# Altium schematic sheet CONFIGURATION_STORAGE_USER_LEDS_BUTTONS.SchDoc(CONFIGURATION_STORAGE_USER_LEDS_BUTTONS)
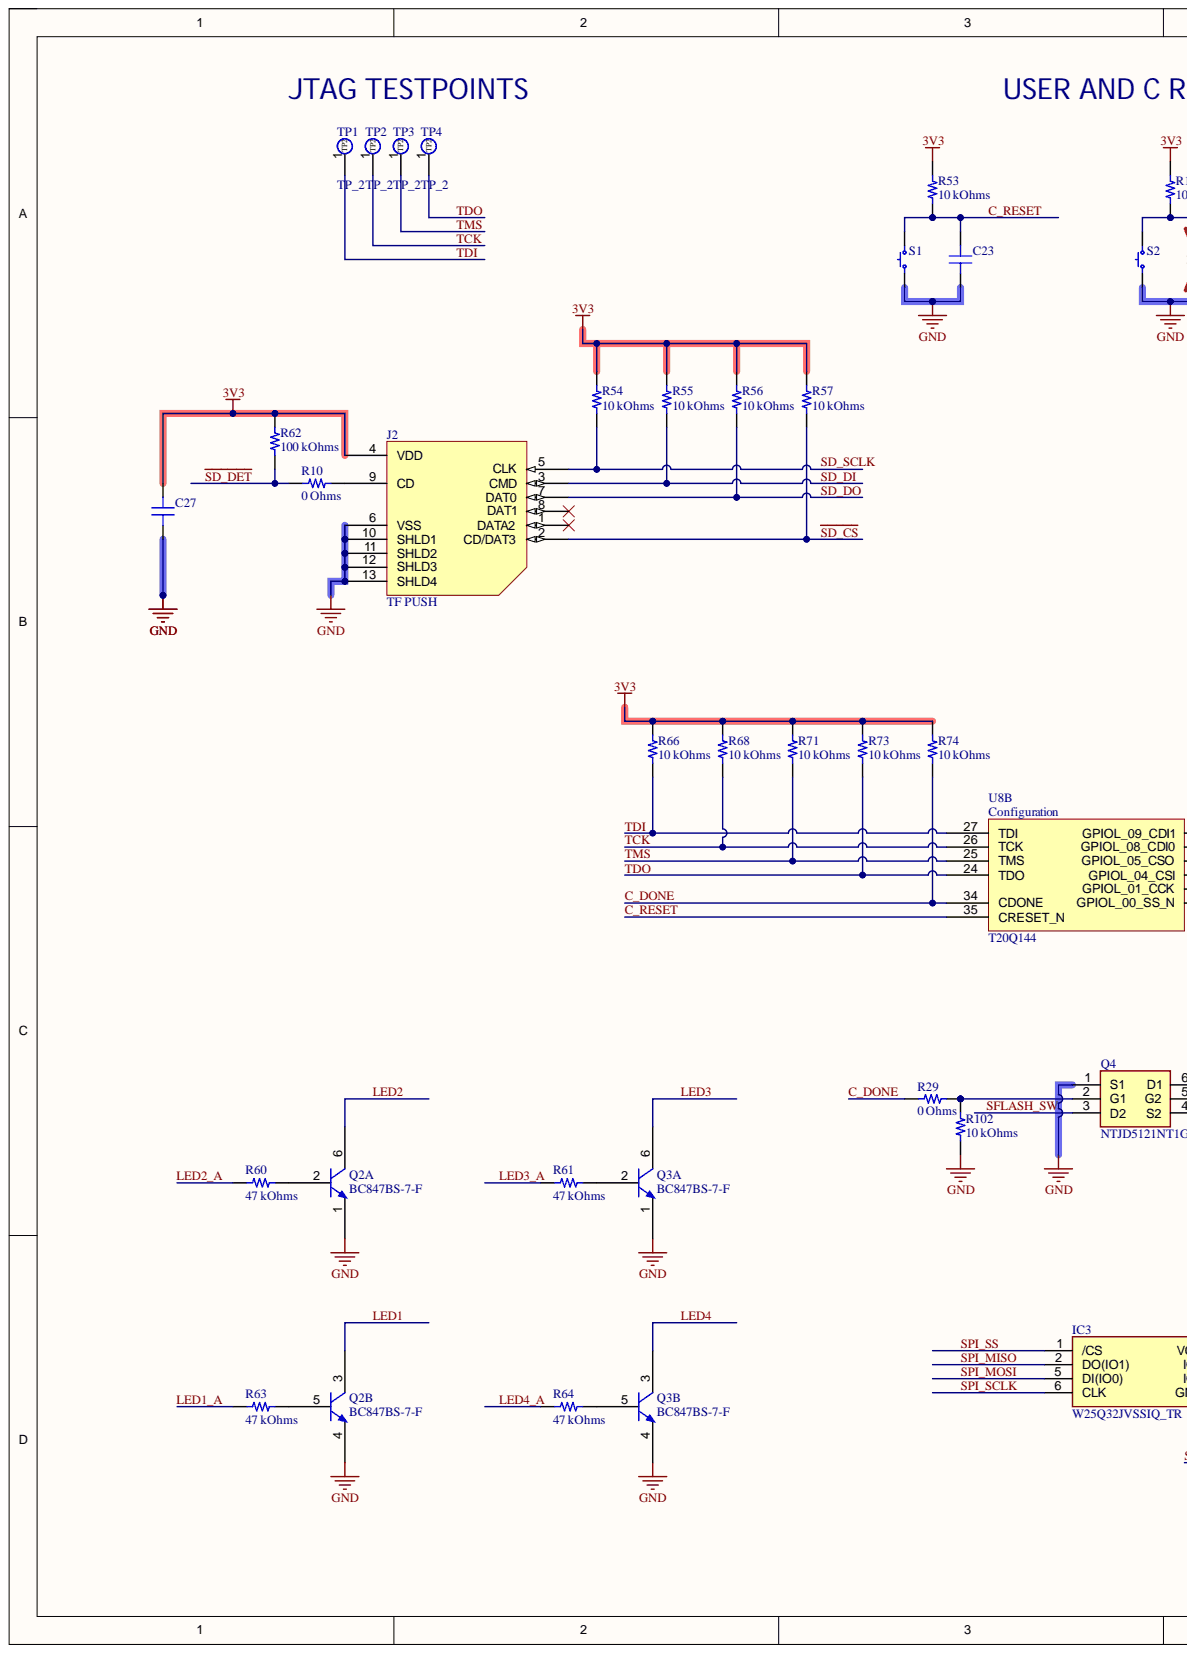
[diagram p0: CONFIGURATION_STORAGE_USER_LEDS_BUTTONS.SchDoc, left]
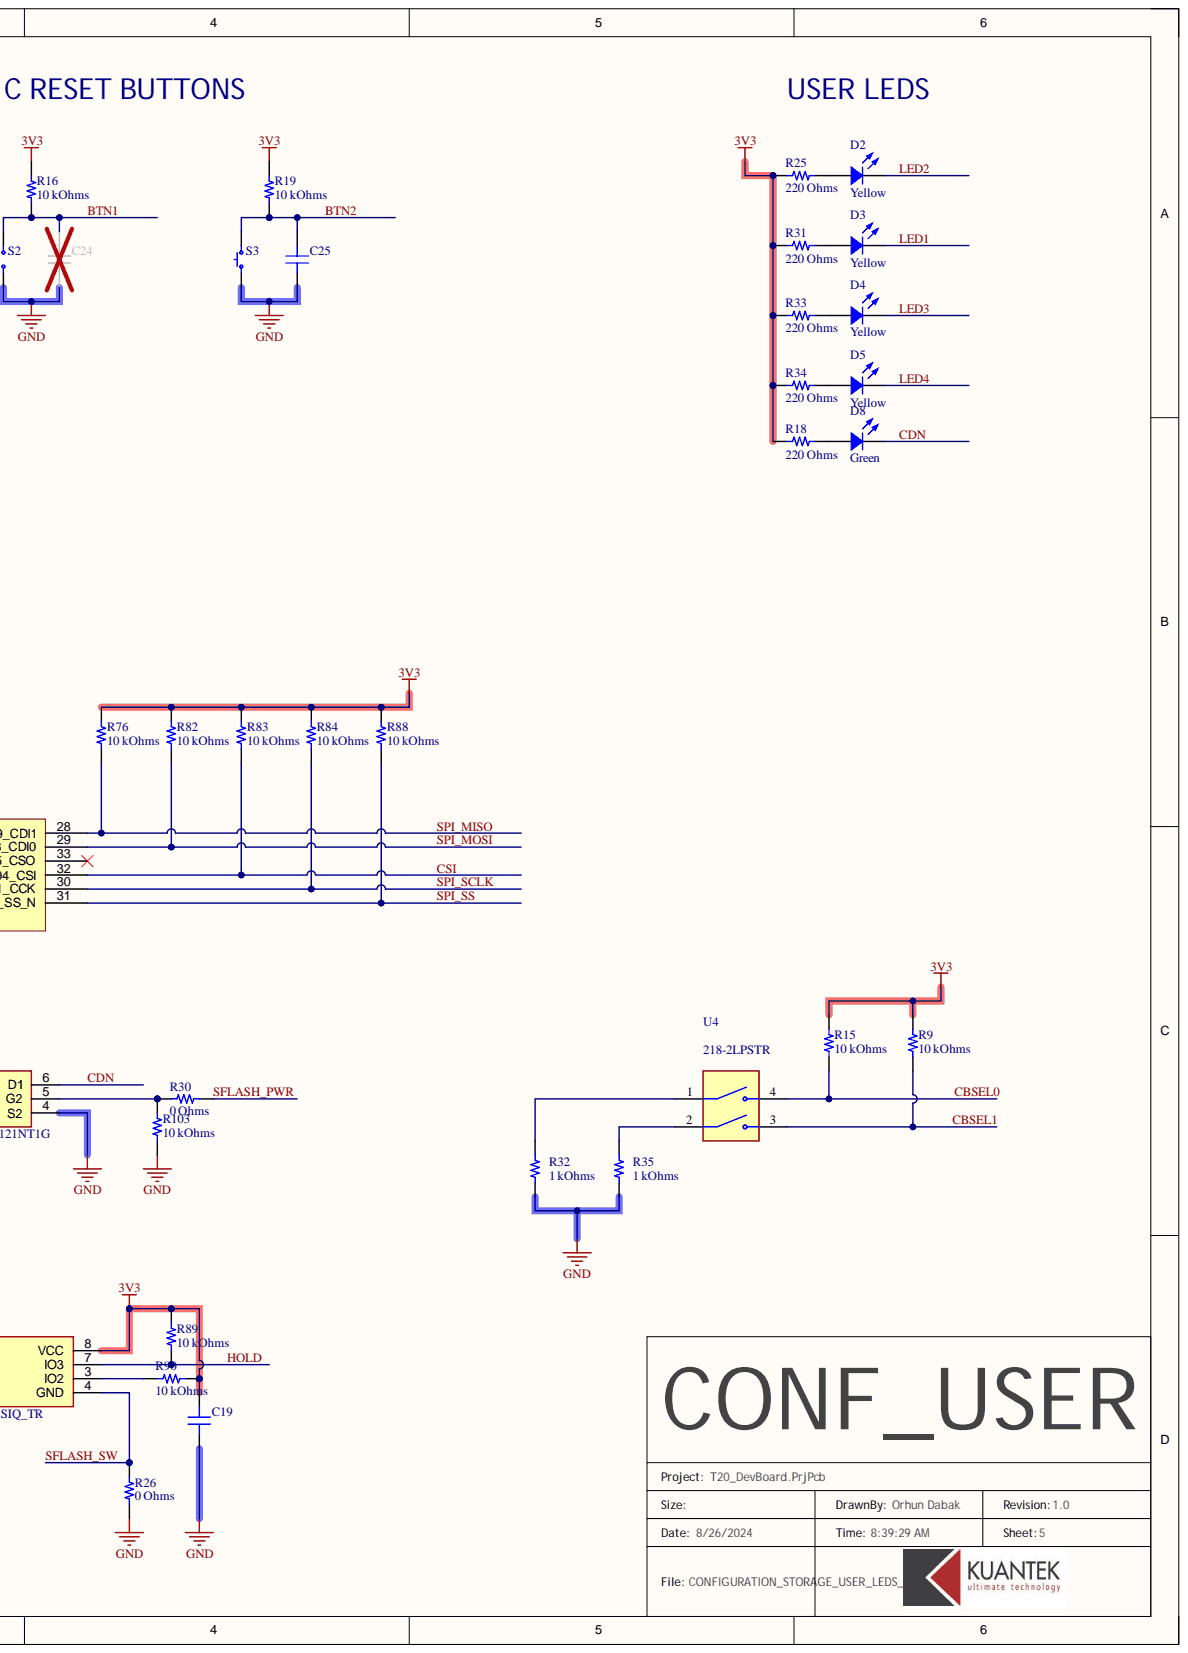
[diagram p1: CONFIGURATION_STORAGE_USER_LEDS_BUTTONS.SchDoc, right]

## Parts
C19  0.1 µF  [CAP 0402_1005]  (value not drawn)
C23  10 µF  [CAP 0402_1005]  (value not drawn)
C24  10 µF  [CAP 0402_1005]  (value not drawn)
C25  10 µF  [CAP 0402_1005]  (value not drawn)
C27  0.1 µF  [CAP 0402_1005]  (value not drawn)
D2  Yellow  [LED 0603_1608 YELLOW]
D3  Yellow  [LED 0603_1608 YELLOW]
D4  Yellow  [LED 0603_1608 YELLOW]
D5  Yellow  [LED 0603_1608 YELLOW]
D8  Green  [LED 0603_1608 GREEN]
IC3  W25Q32JVSSIQ_TR  [SOIC127P790X216-8N]
J2  TF PUSH  [TF PUSH]
Q2  BC847BS-7-F  [BC847BS-7-F-Footprint-1]  (parts A,B)
Q3  BC847BS-7-F  [BC847BS-7-F-Footprint-1]  (parts A,B)
Q4  NTJD5121NT1G  [SOT65P210X110-6N]
R9  10 kOhms  [RES 0402_1005]
R10  0 Ohms  [RES 0402_1005]
R15  10 kOhms  [RES 0402_1005]
R16  10 kOhms  [RES 0402_1005]
R18  220 Ohms  [RES 0402_1005]
R19  10 kOhms  [RES 0402_1005]
R25  220 Ohms  [RES 0402_1005]
R26  0 Ohms  [RES 0402_1005]
R29  0 Ohms  [RES 0402_1005]
R30  0 Ohms  [RES 0402_1005]
R31  220 Ohms  [RES 0402_1005]
R32  1 kOhms  [RES 0402_1005]
R33  220 Ohms  [RES 0402_1005]
R34  220 Ohms  [RES 0402_1005]
R35  1 kOhms  [RES 0402_1005]
R53  10 kOhms  [RES 0402_1005]
R54  10 kOhms  [RES 0402_1005]
R55  10 kOhms  [RES 0402_1005]
R56  10 kOhms  [RES 0402_1005]
R57  10 kOhms  [RES 0402_1005]
R60  47 kOhms  [RES 0402_1005]
R61  47 kOhms  [RES 0402_1005]
R62  100 kOhms  [RES 0402_1005]
R63  47 kOhms  [RES 0402_1005]
R64  47 kOhms  [RES 0402_1005]
R66  10 kOhms  [RES 0402_1005]
R68  10 kOhms  [RES 0402_1005]
R71  10 kOhms  [RES 0402_1005]
R73  10 kOhms  [RES 0402_1005]
R74  10 kOhms  [RES 0402_1005]
R76  10 kOhms  [RES 0402_1005]
R82  10 kOhms  [RES 0402_1005]
R83  10 kOhms  [RES 0402_1005]
R84  10 kOhms  [RES 0402_1005]
R88  10 kOhms  [RES 0402_1005]
R89  10 kOhms  [RES 0402_1005]
R90  10 kOhms  [RES 0402_1005]
R102  10 kOhms  [RES 0402_1005]
R103  10 kOhms  [RES 0402_1005]
S1  TS342A2P-WZ  [TS342A2P-WZ]  (value not drawn)
S2  TS342A2P-WZ  [TS342A2P-WZ]  (value not drawn)
S3  TS342A2P-WZ  [TS342A2P-WZ]  (value not drawn)
TP1  TP_2  [TEST POINT]  (no BOM)
TP2  TP_2  [TEST POINT]  (no BOM)
TP3  TP_2  [TEST POINT]  (no BOM)
TP4  TP_2  [TEST POINT]  (no BOM)
U4  218-2LPSTR  [*218-2LPSTR_CTS]
U8  T20Q144  [CQFP50P2200X2200X160-144N]  (part B)

## Nets
3V3: C19.2 C27.2 IC3.8(VCC) J2.4(VDD) R9.2 R15.2 R16.2 R18.1 R19.2 R25.1 R31.1 R33.1 R34.1 R53.2 R54.2 R55.2 R56.2 R57.2 R62.2 R66.2 R68.2 R71.2 R73.2 R74.2 R76.2 R82.2 R83.2 R84.2 R88.2 R89.2 R90.1
GND: C19.1 C23.1 C24.1 C25.1 C27.1 J2.6(VSS) J2.10(SHLD1) J2.11(SHLD2) J2.12(SHLD3) J2.13(SHLD4) Q2.1 Q2.4 Q3.1 Q3.4 Q4.1(S1) Q4.4(S2) R26.1 R32.1 R35.1 R102.1 R103.1 S1.1 S2.1 S3.1
BTN1: C24.2 R16.1 S2.2
BTN2: C25.2 R19.1 S3.2
C_DONE: R29.1 R74.1 U8.34(CDONE)
C_RESET: C23.2 R53.1 S1.2 U8.35(CRESET_N)
CBSEL0: R15.1 U4.4
CBSEL1: R9.1 U4.3
CDN: D8.2 Q4.6(D1)
CSI: R83.1 U8.32(GPIOL_04_CSI)
HOLD: IC3.7(IO3) R89.1
LED1: D3.2 Q2.3
LED1_A: R63.1
LED2: D2.2 Q2.6
LED2_A: R60.1
LED3: D4.2 Q3.6
LED3_A: R61.1
LED4: D5.2 Q3.3
LED4_A: R64.1
~{SD_CS}: J2.2(CD/DAT3) R57.1
~{SD_DET}: R10.1 R62.1
SD_DI: J2.3(CMD) R55.1
SD_DO: J2.7(DAT0) R56.1
SD_SCLK: J2.5(CLK) R54.1
SFLASH_PWR: R30.2
SFLASH_SW: IC3.4(GND) Q4.3(D2) R26.2
SPI_MISO: IC3.2(DO(IO1)) R76.1 U8.28(GPIOL_09_CDI1)
SPI_MOSI: IC3.5(DI(IO0)) R82.1 U8.29(GPIOL_08_CDI0)
SPI_SCLK: IC3.6(CLK) R84.1 U8.30(GPIOL_01_CCK)
SPI_SS: IC3.1(/CS) R88.1 U8.31(GPIOL_00_SS_N)
TCK: R68.1 TP2.1 U8.26(TCK)
TDI: R66.1 TP1.1 U8.27(TDI)
TDO: R73.1 TP4.1 U8.24(TDO)
TMS: R71.1 TP3.1 U8.25(TMS)
NetD2_1: D2.1 R25.2
NetD3_1: D3.1 R31.2
NetD4_1: D4.1 R33.2
NetD5_1: D5.1 R34.2
NetD8_1: D8.1 R18.2
NetIC3_3: IC3.3(IO2) R90.2
NetJ2_9: J2.9(CD) R10.2
NetQ2_2: Q2.2 R60.2
NetQ2_5: Q2.5 R63.2
NetQ3_2: Q3.2 R61.2
NetQ3_5: Q3.5 R64.2
NetQ4_2: Q4.2(G1) R29.2 R102.2
NetQ4_5: Q4.5(G2) R30.1 R103.2
NetR32_2: R32.2 U4.1
NetR35_2: R35.2 U4.2

Not connected: J2 1(DATA2) 8(DAT1); U8 33(GPIOL_05_CSO)

## Library data of the parts
C19 C27 = CC0402KRX7R6BB104: CAP CER 0.1UF 10V X7R 0402; manufacturer: Yageo; Database Table Name: Capacitors - Ceramic - 0402; Tolerance: ±10%; Applications: General Purpose; Capacitance: 0.1 µF; Component Kind: Standard; componentlink1description: Datasheet; componentlink1url: https://www.yageo.com/upload/media/product/productsearch/datasheet/mlcc/UPY-GPHC_X7R_6.3V-to-50V_18.pdf; componentlink2description: DigiKey Link; componentlink2url: https://www.digikey.com/en/products/detail/yageo/CC0402KRX7R6BB104/2103083; Device Package: 0402 (1005 Metric); lastupdated: 19/09/2022 12:57:28; Library Name: Celestial Altium Library - altium_library.DbLib; Lifecycle Status: Active; Minimum Order: 1; Mounting Type: Surface Mount, MLCC; Operating Temperature: -55°C ~ 125°C; Package / Case: 0402 (1005 Metric); packaging: CutTape; Part Number: CC0402KRX7R6BB104; Part Status: Active; partid: 3877; price: 0.01; series: CC; Size / Dimension: 0.039" L x 0.020" W (1.00mm x 0.50mm); Supplier 1: DigiKey; Supplier Part Number 1: 311-1345-1-ND; Temperature Coefficient: X7R; Thickness (Max): 0.022" (0.55mm); Value: 0.1 µF; Voltage - Rated: 10V
C23-C25 = GRM155R61A106ME11D: CAP CER 10UF 10V X5R 0402; manufacturer: Murata Electronics; Database Table Name: Capacitors - Ceramic - 0402; Tolerance: ±20%; Applications: General Purpose; Capacitance: 10 µF; Component Kind: Standard; componentlink2description: DigiKey Link; componentlink2url: https://www.digikey.com/en/products/detail/murata-electronics/GRM155R61A106ME11D/12091056; Device Package: 0402 (1005 Metric); lastupdated: 19/09/2022 12:56:37; Library Name: Celestial Altium Library - altium_library.DbLib; Lifecycle Status: Active; Minimum Order: 1; Mounting Type: Surface Mount, MLCC; Operating Temperature: -55°C ~ 85°C; Package / Case: 0402 (1005 Metric); packaging: TapeAndReel; Part Number: GRM155R61A106ME11D; Part Status: Active; partid: 45464; price: 0.02; series: GRM; Size / Dimension: 0.039" L x 0.020" W (1.00mm x 0.50mm); Supplier 1: DigiKey; Supplier Part Number 1: 490-GRM155R61A106ME11DCT-ND; Temperature Coefficient: X5R; Thickness (Max): 0.028" (0.70mm); Value: 10 µF; Voltage - Rated: 10V
D2-D5 = SML-LX0603YW-TR: LED YELLOW DIFFUSED SMD; Manufacturer: Lumex Opto/Components Inc.; Configuration: Standard; Current - Test: 20mA; Database Table Name: LED - Indication; Lens Color: White; Lens Size: 1.00mm x 0.80mm; Lens Style: Rectangle with Flat Top; Millicandela Rating: 14mcd; Viewing Angle: 160°; Voltage - Forward (Vf) (Typ): 2.1V; Wavelength - Peak: 585nm; Color: Yellow; Component Kind: Standard; ComponentLink1Description: Datasheet; ComponentLink1URL: https://www.lumex.com/content/files/ProductAttachment/SML-LX0603YW-TR.pdf; ComponentLink2Description: DigiKey Link; ComponentLink2URL: https://www.digikey.com/en/products/detail/lumex-opto-components-inc/SML-LX0603YW-TR/304361; Device Package: 0603 (1608 Metric); Height (Max): 0.60mm; LastUpdated: 19/09/2022 13:51:05; Lens Transparency: Diffused; Library Name: Celestial Altium Library - altium_library.DbLib; Lifecycle Status: Active; Minimum Order: 1; Mounting Type: Surface Mount; Package / Case: 0603 (1608 Metric); Packaging: TapeAndReel; Part Number: SML-LX0603YW-TR; Part Status: Active; PartId: 92; Price: 0.05; Series: -; Size / Dimension: 1.60mm L x 0.80mm W; Supplier 1: DigiKey; Supplier Part Number 1: 67-1550-1-ND; Value: Yellow
D8 = LSM0603453V: LED SM 0603 3V -/1000/-MCD GREEN; Manufacturer: Visual Communications Company - VCC; Configuration: Standard; Current - Test: 20mA; Database Table Name: LED - Indication; Lens Color: Colorless; Lens Size: 1.20mm x 0.80mm; Lens Style: Rectangle with Flat Top; Lens Transparency: Clear; Millicandela Rating: 63mcd; Viewing Angle: 120°; Voltage - Forward (Vf) (Typ): 2V; Wavelength - Dominant: 572nm; Wavelength - Peak: 571nm; Color: Green; Component Kind: Standard; ComponentLink1Description: Datasheet; ComponentLink1URL: https://vcclite.com/wp-content/uploads/wpallimport/files/files/LSM0603453V.pdf; ComponentLink2Description: DigiKey Link; ComponentLink2URL: https://www.digikey.com/en/products/detail/visual-communications-company-vcc/LSM0603453V/9486724; Device Package: 0603 (1608 Metric); Height (Max): 0.65mm; LastUpdated: 19/09/2022 13:49:59; Library Name: Celestial Altium Library - altium_library.DbLib; Lifecycle Status: Active; Minimum Order: 1; Mounting Type: Surface Mount; Package / Case: 0603 (1608 Metric); Packaging: TapeAndReel; Part Number: LSM0603453V; Part Status: Active; PartId: 579; Price: 0.05; Series: LSM0603; Size / Dimension: 1.60mm L x 0.80mm W; Supplier 1: DigiKey; Supplier Device Package: Chip LED; Supplier Part Number 1: LSM0603453VCT-ND; Value: Green
IC3 = W25Q32JVSSIQ_TR: Integrated Circuit; Manufacturer_Name: Winbond; Manufacturer_Part_Number: W25Q32JVSSIQ TR; Arrow Part Number: W25Q32JVSSIQ TR; Arrow Price/Stock: https://www.arrow.com/en/products/w25q32jvssiqtr/winbond-electronics?region=nac; Component Kind: Standard; Datasheet Link: https://www.winbond.com/resource-files/w25q32jv%20spi%20revc%2008302016.pdf; Height: 2.16mm; Library Name: SamacSys.SchLib; Mouser Part Number: 454-W25Q32JVSSIQTR; Mouser Price/Stock: https://www.mouser.co.uk/ProductDetail/Winbond/W25Q32JVSSIQ-TR?qs=qSfuJ%252Bfl%2Fd73AdQzQa%2FEuA%3D%3D
J2 = TF PUSH: -20℃~+90℃ 2mm Deck MicroSD card (TF card) Self bomb SMD SD Card Connectors ROHS; Manufacturer: SHOU HAN; Card Connection Mode: Self bomb; Card Type: MicroSD card (TF card); Category: Connectors/SD Card Connectors; Component Kind: Standard; ComponentLink1Description: Datasheet; ComponentLink1URL: https://datasheet.lcsc.com/lcsc/1912111437_SHOU-HAN-TF-PUSH_C393941.pdf; ComponentLink2Description: LCSC Link; ComponentLink2URL: https://www.lcsc.com/product-detail/SD-Card-Connectors_SHOU-HAN-TF-PUSH_C393941.html; Connector Type: Deck; LCSC Part Number: C393941; Library Name: T8_devkit_PCB.SchLib; Manufacturer Part Number: TF PUSH; Maximum Body Height: 2mm; Operating Temperature Range: -20℃~+90℃; Package: SMD
Q2 Q3 = CMP-013-00035-3: 2NPN Ic=100mA Vceo=45V hfe=200~450 P=200mW SOT363; Component Kind: Standard; Library Name: Kocaeli University
Q4 = NTJD5121NT1G: Transistor; Manufacturer_Name: onsemi; Arrow Part Number: NTJD5121NT1G; Arrow Price/Stock: https://www.arrow.com/en/products/ntjd5121nt1g/on-semiconductor?region=nac; Component Kind: Standard; Datasheet Link: http://www.onsemi.com/pub/Collateral/NTJD5121N-D.PDF; Height: 1.1mm; Library Name: SamacSys.SchLib; Manufacturer_Part_Number: NTJD5121NT1G; Mouser Part Number: 863-NTJD5121NT1G; Mouser Price/Stock: https://www.mouser.co.uk/ProductDetail/onsemi/NTJD5121NT1G?qs=E94NKttrCwxgtZwNB18zeg%3D%3D
R9 R15 R16 R19 R53-R57 R66 R68 R71 R73 R74 R76 R82-R84 R88-R90 R102 R103 = RC0402JR-0710KL: RES 10K OHM 5% 1/16W 0402; Manufacturer: Yageo; Database Table Name: Resistors - Surface Mount - 0402; Power (Watts): 0.063W, 1/16W; Temperature Coefficient: ±100ppm/°C; Component Kind: Standard; ComponentLink1Description: Datasheet; ComponentLink1URL: https://www.yageo.com/upload/media/product/productsearch/datasheet/rchip/PYu-RC_Group_51_RoHS_L_10.pdf; ComponentLink2Description: DigiKey Link; ComponentLink2URL: https://www.digikey.com/en/products/detail/yageo/RC0402JR-0710KL/726418; Composition: Thick Film; Device Package: 0402 (1005 Metric); Features: Moisture Resistant; Height - Seated (Max): 0.016" (0.40mm); LastUpdated: 4/7/2023 07:22:44 PM; Library Name: Celestial Altium Library - altium_library.DbLib; Lifecycle Status: Active; Minimum Order: 1; Number of Terminations: 2; Operating Temperature: -55°C ~ 155°C; Package / Case: 0402 (1005 Metric); Packaging: CutTape; Part Number: RC0402JR-0710KL; Part Status: Active; PartId: 20526; Price: 0.01; Resistance: 10 kOhms; Series: RC_L; Size / Dimension: 0.039" L x 0.020" W (1.00mm x 0.50mm); Supplier 1: DigiKey; Supplier Device Package: 0402; Supplier Part Number 1: 311-10KJRCT-ND; Tolerance: ±5%; Value: 10 kOhms
R10 R26 R29 R30 = RC0402JR-070RL: RES 0 OHM JUMPER 1/16W 0402; Manufacturer: Yageo; Database Table Name: Resistors - Surface Mount - 0402; Power (Watts): 0.063W, 1/16W; Component Kind: Standard; ComponentLink1Description: Datasheet; ComponentLink1URL: https://www.yageo.com/upload/media/product/productsearch/datasheet/rchip/PYu-RC_Group_51_RoHS_L_10.pdf; ComponentLink2Description: DigiKey Link; ComponentLink2URL: https://www.digikey.com/en/products/detail/yageo/RC0402JR-070RL/726406; Composition: Thick Film; Device Package: 0402 (1005 Metric); Features: Moisture Resistant; Height - Seated (Max): 0.016" (0.40mm); LastUpdated: 1/2/2023 08:20:46 PM; Library Name: Celestial Altium Library - altium_library.DbLib; Lifecycle Status: Active; Minimum Order: 1; Number of Terminations: 2; Operating Temperature: -55°C ~ 155°C; Package / Case: 0402 (1005 Metric); Packaging: CutTape; Part Number: RC0402JR-070RL; Part Status: Active; PartId: 17588; Price: 0.01; Resistance: 0 Ohms; Series: RC_L; Size / Dimension: 0.039" L x 0.020" W (1.00mm x 0.50mm); Supplier 1: DigiKey; Supplier Device Package: 0402; Supplier Part Number 1: 311-0.0JRCT-ND; Tolerance: Jumper; Value: 0 Ohms
R18 R25 R31 R33 R34 = RC0402FR-07220RL: RES 220 OHM 1% 1/16W 0402; Manufacturer: Yageo; Database Table Name: Resistors - Surface Mount - 0402; Power (Watts): 0.063W, 1/16W; Temperature Coefficient: ±100ppm/°C; Component Kind: Standard; ComponentLink1Description: Datasheet; ComponentLink1URL: https://www.yageo.com/upload/media/product/productsearch/datasheet/rchip/PYu-RC_Group_51_RoHS_L_10.pdf; ComponentLink2Description: DigiKey Link; ComponentLink2URL: https://www.digikey.com/en/products/detail/yageo/RC0402FR-07220RL/726565; Composition: Thick Film; Device Package: 0402 (1005 Metric); Features: Moisture Resistant; Height - Seated (Max): 0.016" (0.40mm); LastUpdated: 19/09/2022 13:14:14; Library Name: Celestial Altium Library - altium_library.DbLib; Lifecycle Status: Active; Minimum Order: 1; Number of Terminations: 2; Operating Temperature: -55°C ~ 155°C; Package / Case: 0402 (1005 Metric); Packaging: CutTape; Part Number: RC0402FR-07220RL; Part Status: Active; PartId: 17956; Price: 0.01; Resistance: 220 Ohms; Series: RC_L; Size / Dimension: 0.039" L x 0.020" W (1.00mm x 0.50mm); Supplier 1: DigiKey; Supplier Device Package: 0402; Supplier Part Number 1: 311-220LRCT-ND; Tolerance: ±1%; Value: 220 Ohms
R32 R35 = AC0402FR-071KL: RES SMD 1K OHM 1% 1/16W 0402; Manufacturer: Yageo; Database Table Name: Resistors - Surface Mount - 0402; Power (Watts): 0.063W, 1/16W; Temperature Coefficient: ±100ppm/°C; Component Kind: Standard; ComponentLink1Description: Datasheet; ComponentLink1URL: https://www.yageo.com/upload/media/product/productsearch/datasheet/rchip/PYu-AC_51_RoHS_L_7.pdf; ComponentLink2Description: DigiKey Link; ComponentLink2URL: https://www.digikey.com/en/products/detail/yageo/AC0402FR-071KL/2827801; Composition: Thick Film; Device Package: 0402 (1005 Metric); Features: Automotive AEC-Q200, Moisture Resistant; Height - Seated (Max): 0.015" (0.37mm); LastUpdated: 19/09/2022 13:14:31; Library Name: Celestial Altium Library - altium_library.DbLib; Lifecycle Status: Active; Minimum Order: 1; Number of Terminations: 2; Operating Temperature: -55°C ~ 155°C; Package / Case: 0402 (1005 Metric); Packaging: CutTape; Part Number: AC0402FR-071KL; Part Status: Active; PartId: 12037; Price: 0.01; Ratings: AEC-Q200; Resistance: 1 kOhms; Series: AC; Size / Dimension: 0.039" L x 0.020" W (1.00mm x 0.50mm); Supplier 1: DigiKey; Supplier Device Package: 0402; Supplier Part Number 1: 311-1KLBCT-ND; Tolerance: ±1%; Value: 1 kOhms
R60 R61 R63 R64 = RMCF0402FT47K0: RES 47K OHM 1% 1/16W 0402; Manufacturer: Stackpole Electronics Inc; Database Table Name: Resistors - Surface Mount - 0402; Power (Watts): 0.063W, 1/16W; Temperature Coefficient: ±100ppm/°C; Component Kind: Standard; ComponentLink1Description: Datasheet; ComponentLink1URL: https://www.seielect.com/catalog/sei-rmcf_rmcp.pdf; ComponentLink2Description: DigiKey Link; ComponentLink2URL: https://www.digikey.com/en/products/detail/stackpole-electronics-inc/RMCF0402FT47K0/1714749; Composition: Thick Film; Device Package: 0402 (1005 Metric); Features: Automotive AEC-Q200; Height - Seated (Max): 0.016" (0.40mm); LastUpdated: 1/2/2023 08:21:41 PM; Library Name: Celestial Altium Library - altium_library.DbLib; Lifecycle Status: Active; Minimum Order: 1; Number of Terminations: 2; Operating Temperature: -55°C ~ 155°C; Package / Case: 0402 (1005 Metric); Packaging: CutTape; Part Number: RMCF0402FT47K0; Part Status: Active; PartId: 4957; Price: 0.01; Ratings: AEC-Q200; Resistance: 47 kOhms; Series: RMCF; Size / Dimension: 0.039" L x 0.020" W (1.00mm x 0.50mm); Supplier 1: DigiKey; Supplier Device Package: 0402; Supplier Part Number 1: RMCF0402FT47K0CT-ND; Tolerance: ±1%; Value: 47 kOhms
R62 = RT0402FRE07100KL: RES SMD 100K OHM 1% 1/16W 0402; Manufacturer: Yageo; Database Table Name: Resistors - Surface Mount - 0402; Power (Watts): 0.063W, 1/16W; Temperature Coefficient: ±50ppm/°C; Component Kind: Standard; ComponentLink1Description: Datasheet; ComponentLink1URL: https://www.yageo.com/upload/media/product/productsearch/datasheet/rchip/PYu-RT_1-to-0.01_RoHS_L_11.pdf; ComponentLink2Description: DigiKey Link; ComponentLink2URL: https://www.digikey.com/en/products/detail/yageo/RT0402FRE07100KL/1071698; Composition: Thin Film; Device Package: 0402 (1005 Metric); Height - Seated (Max): 0.014" (0.35mm); LastUpdated: 1/2/2023 08:21:49 PM; Library Name: Celestial Altium Library - altium_library.DbLib; Lifecycle Status: Active; Minimum Order: 1; Number of Terminations: 2; Operating Temperature: -55°C ~ 155°C; Package / Case: 0402 (1005 Metric); Packaging: TapeAndReel; Part Number: RT0402FRE07100KL; Part Status: Active; PartId: 3190; Price: 0.01; Resistance: 100 kOhms; Series: RT; Size / Dimension: 0.039" L x 0.020" W (1.00mm x 0.50mm); Supplier 1: DigiKey; Supplier Device Package: 0402; Supplier Part Number 1: YAG2307CT-ND; Tolerance: ±1%; Value: 100 kOhms
S1-S3 = TS342A2P-WZ: NO SMDSplicing 50mA 3.95mm 100MΩ 30000 12V 2.9mm -25℃~+70℃ 2mm Black Round Button Brick nogging SPST SMD Tactile Switches ROHS; Manufacturer: SHOU HAN; Mechanical Life: 30000; Actuator Style: Round Button; Actuator/Cap Color: Black; Circuit: SPST; Component Kind: Standard; ComponentLink1Description: Datasheet; ComponentLink1URL: https://datasheet.lcsc.com/lcsc/2005221232_SHOU-HAN-TS342A2P-WZ_C557591.pdf; ComponentLink2Description: LCSC Link; ComponentLink2URL: https://www.lcsc.com/product-detail/Tactile-Switches_SHOU-HAN-TS342A2P-WZ_C557591.html; Contact Current: 50mA; Insulation Resistance: 100MΩ; JLCPCB Part Number: C557591; Library Name: T8_devkit_PCB.SchLib; Manufacturer Part Number: TS342A2P-WZ; Mounting Style: Brick nogging; Package: SMD; Switch Length: 3.95mm; Switch Width: 2.9mm; Voltage Rating (DC): 12V
TP1-TP4 = TEST POINT_2: 1.5x1.5mm Test Point; Library Name: T8_devkit_PCB_1.SCHLIB
U4 = 218-2LPSTR: Dip Switch SPST 2 Position Surface Mount Slide (Standard) Actuator 25mA 24VDC; MF: CTS Electrocomponents; Check_prices: https://www.snapeda.com/parts/218-2LPSTR/CTS+Electrocomponents/view-part/?ref=eda; Component Kind: Standard; MP: 218-2LPSTR; Package: None; SnapEDA_Link: https://www.snapeda.com/parts/218-2LPSTR/CTS+Electrocomponents/view-part/?ref=snap; Library Name: Kuantek_SO-DIMM.SchLib
U8 = T20Q144: Trion® Field Programmable Gate Array (FPGA) IC 97 1044 19728 144-LQFP; Component Kind: Standard; Library Name: T8_devkit_PCB.SchLib
